# Altium schematic sheet Badge2024_LEDs.SchDoc(Badge2024_LEDs)
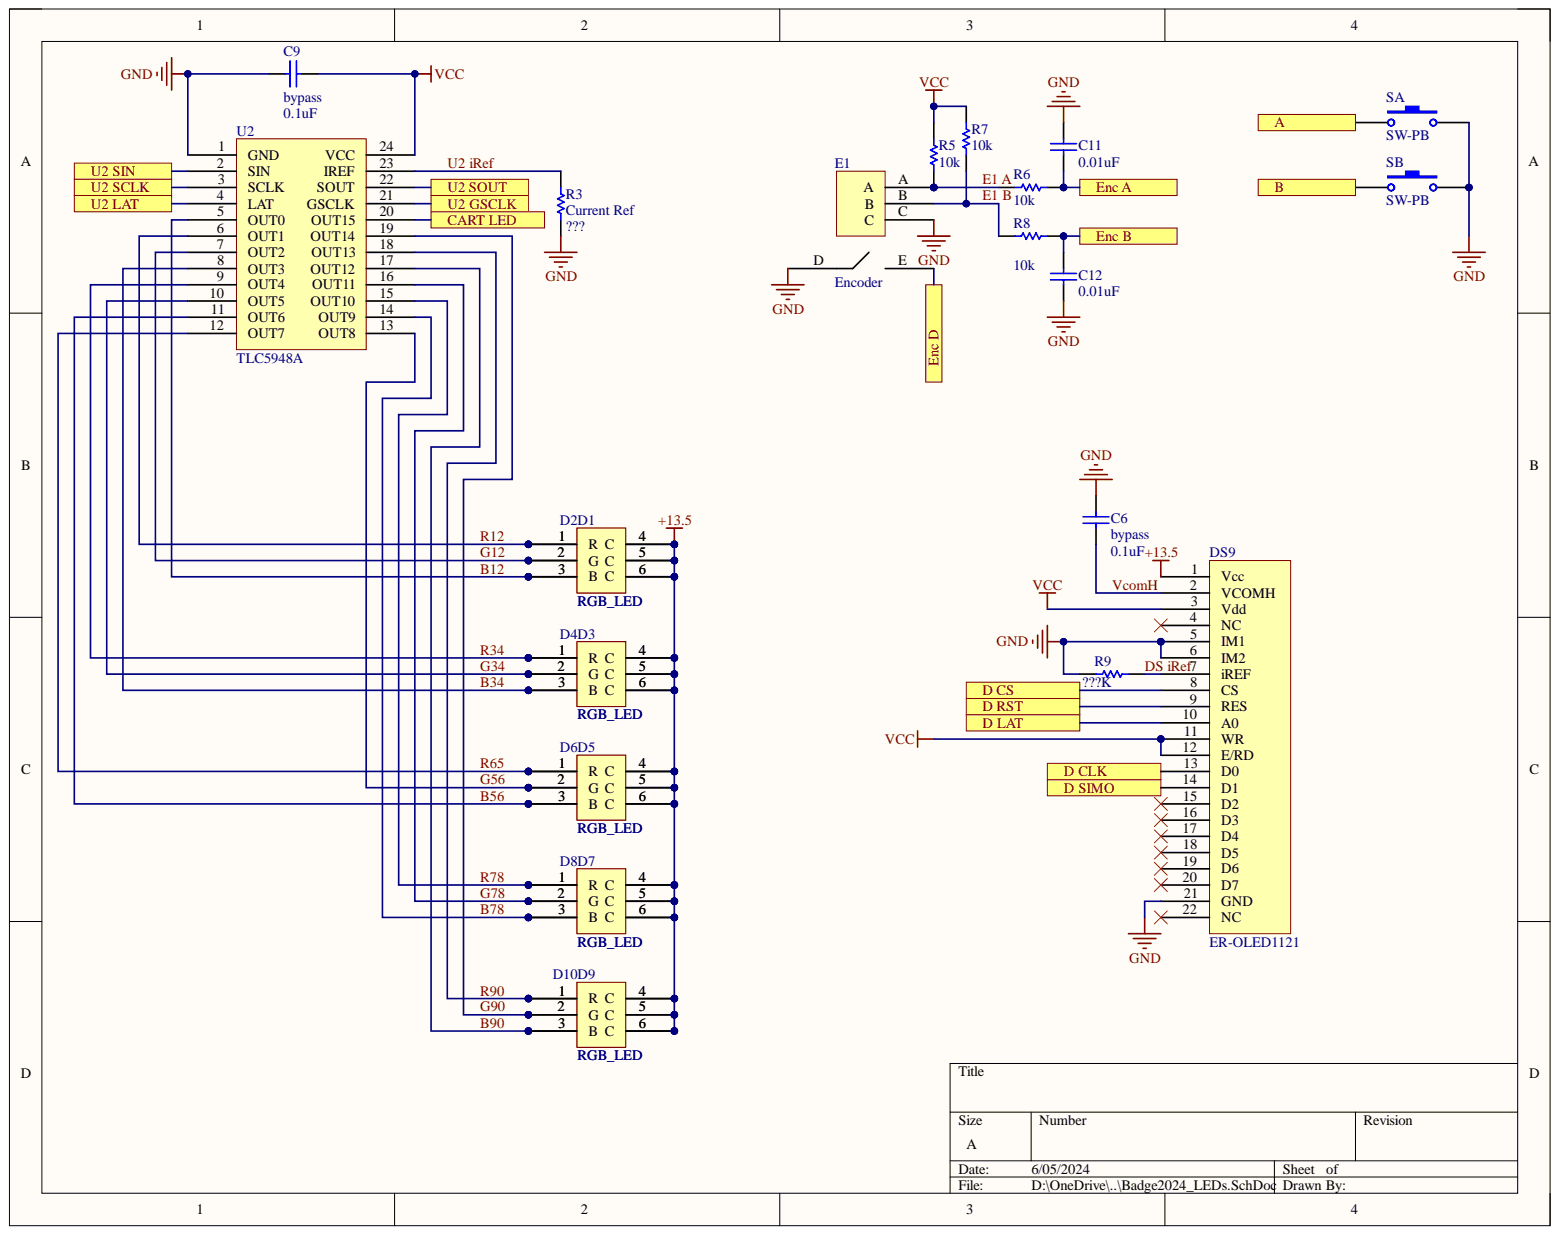
[diagram p0: Badge2024_LEDs.SchDoc]

## Parts
C6  bypass  value 0.1uF  [CAPC0402]
C9  bypass  value 0.1uF  [CAPC0402]
C11  value 0.01uF  [CAPC1608(0603)]  (hidden comment: Cap)
C12  value 0.01uF  [CAPC1608(0603)]  (hidden comment: Cap)
D1  RGB_LED  [LitOn LTST]
D2  RGB_LED  [LitOn LTST]
D3  RGB_LED  [LitOn LTST]
D4  RGB_LED  [LitOn LTST]
D5  RGB_LED  [LitOn LTST]
D6  RGB_LED  [LitOn LTST]
D7  RGB_LED  [LitOn LTST]
D8  RGB_LED  [LitOn LTST]
D9  RGB_LED  [LitOn LTST]
D10  RGB_LED  [LitOn LTST]
DS9  ER-OLED1121  [Display]
E1  Encoder  [PEC111s Encoder]
R3  Current Ref  value ???  [RESC1608(0603)]
R5  value 10k  [RESC1608(0603)]  (hidden comment: Res1)
R6  value 10k  [RESC1608(0603)]  (hidden comment: Res1)
R7  value 10k  [RESC1608(0603)]  (hidden comment: Res1)
R8  value 10k  [RESC1608(0603)]  (hidden comment: Res1)
R9  value ???K  [RESC1608(0603)]  (hidden comment: Res1)
SA  SW-PB  [PTS636 Button Rect]
SB  SW-PB  [PTS636 Button Rect]
U2  TLC5948A  [SOP64P600X175-24L]

## Ports
A, B, CART LED, D CLK, D CS, D LAT, D RST, D SIMO, Enc A, Enc B, Enc D, U2 GSCLK, U2 LAT, U2 SCLK, U2 SIN, U2 SOUT

## Nets
+13.5: D1.4(C) D1.5(C) D1.6(C) D2.4(C) D2.5(C) D2.6(C) D3.4(C) D3.5(C) D3.6(C) D4.4(C) D4.5(C) D4.6(C) D5.4(C) D5.5(C) D5.6(C) D6.4(C) D6.5(C) D6.6(C) D7.4(C) D7.5(C) D7.6(C) D8.4(C) D8.5(C) D8.6(C) D9.4(C) D9.5(C) D9.6(C) D10.4(C) D10.5(C) D10.6(C) DS9.1(Vcc)
GND: C6.2 C9.1 C11.2 C12.1 DS9.5(IM1) DS9.6(IM2) DS9.21(GND) E1.C E1.D R3.1 R9.1 SA.2 SB.2 U2.1(GND)
VCC: C9.2 DS9.3(Vdd) DS9.11(WR) DS9.12(E/RD) R5.1 R7.1 U2.24(VCC)
A: SA.1 ; port A
B: SB.1 ; port B
B12: D1.3(B) D2.3(B) U2.5(OUT0)
B34: D3.3(B) D4.3(B) U2.8(OUT3)
B56: D5.3(B) D6.3(B) U2.11(OUT6)
B78: D7.3(B) D8.3(B) U2.14(OUT9)
B90: D9.3(B) D10.3(B) U2.17(OUT12)
CART LED: U2.20(OUT15) ; port CART LED
D CLK: DS9.13(D0) ; port D CLK
D CS: DS9.8(CS) ; port D CS
D LAT: DS9.10(A0) ; port D LAT
D RST: DS9.9(RES) ; port D RST
D SIMO: DS9.14(D1) ; port D SIMO
DS iRef: DS9.7(iREF) R9.2
E1 A: E1.A R5.2 R6.2
E1 B: E1.B R7.2 R8.2
Enc A: C11.1 R6.1 ; port Enc A
Enc B: C12.2 R8.1 ; port Enc B
Enc D: E1.E ; port Enc D
G12: D1.2(G) D2.2(G) U2.7(OUT2)
G34: D3.2(G) D4.2(G) U2.10(OUT5)
G56: D5.2(G) D6.2(G) U2.13(OUT8)
G78: D7.2(G) D8.2(G) U2.16(OUT11)
G90: D9.2(G) D10.2(G) U2.19(OUT14)
R12: D1.1(R) D2.1(R) U2.6(OUT1)
R34: D3.1(R) D4.1(R) U2.9(OUT4)
R65: D5.1(R) D6.1(R) U2.12(OUT7)
R78: D7.1(R) D8.1(R) U2.15(OUT10)
R90: D9.1(R) D10.1(R) U2.18(OUT13)
U2 GSCLK: U2.21(GSCLK) ; port U2 GSCLK
U2 iRef: R3.2 U2.23(IREF)
U2 LAT: U2.4(LAT) ; port U2 LAT
U2 SCLK: U2.3(SCLK) ; port U2 SCLK
U2 SIN: U2.2(SIN) ; port U2 SIN
U2 SOUT: U2.22(SOUT) ; port U2 SOUT
VcomH: C6.1 DS9.2(VCOMH)

Not connected: DS9 4(NC) 15(D2) 16(D3) 17(D4) 18(D5) 19(D6) 20(D7) 22(NC)

## Library data of the parts
C6 C9 C11 C12 = Cap: Capacitor; Component Kind: Standard; LatestRevisionDate: 17-Jul-2002; LatestRevisionNote: Re-released for DXP Platform.; Library Name: Miscellaneous Devices.IntLib; PackageDescription: Radial Cap, Thru-Hole; 2 Leads; 0.3 in Pin Spacing; PackageReference: RAD-0.3; Published: 8-Jun-2000; Signal Integrity: Cap; Simulation: CAP; Publisher: Altium Limited
R3 R5-R9 = Res1: Resistor; Component Kind: Standard; LatestRevisionDate: 17-Jul-2002; LatestRevisionNote: Re-released for DXP Platform.; Library Name: Miscellaneous Devices.IntLib; PackageDescription: Axial Device, Thru-Hole; 2 Leads; 0.3 in Pin Spacing; PackageReference: AXIAL-0.3; Published: 8-Jun-2000; Signal Integrity: Res; Simulation: RESISTOR; Publisher: Altium Limited
SA SB = SW-PB: Switch; Component Kind: Standard; LatestRevisionDate: 17-Jul-2002; LatestRevisionNote: Re-released for DXP Platform.; Library Name: Miscellaneous Devices.IntLib; PackageDescription: Switch; 2 Leads; PackageReference: SPST-2; Published: 24-Mar-1999; Publisher: Altium Limited
D1-D10 = RGB_LED: Component Kind: Standard; Library Name: 2024.SchLib
DS9 = ER-OLED1121: Component Kind: Standard; Library Name: 2024.SchLib
E1 = Encoder: Component Kind: Standard; Library Name: 2024.SchLib
U2 = TLC5948A: Component Kind: Standard; Library Name: 2024.SchLib
